# Altium schematic sheet Board_Power.SchDoc(Board_Power)
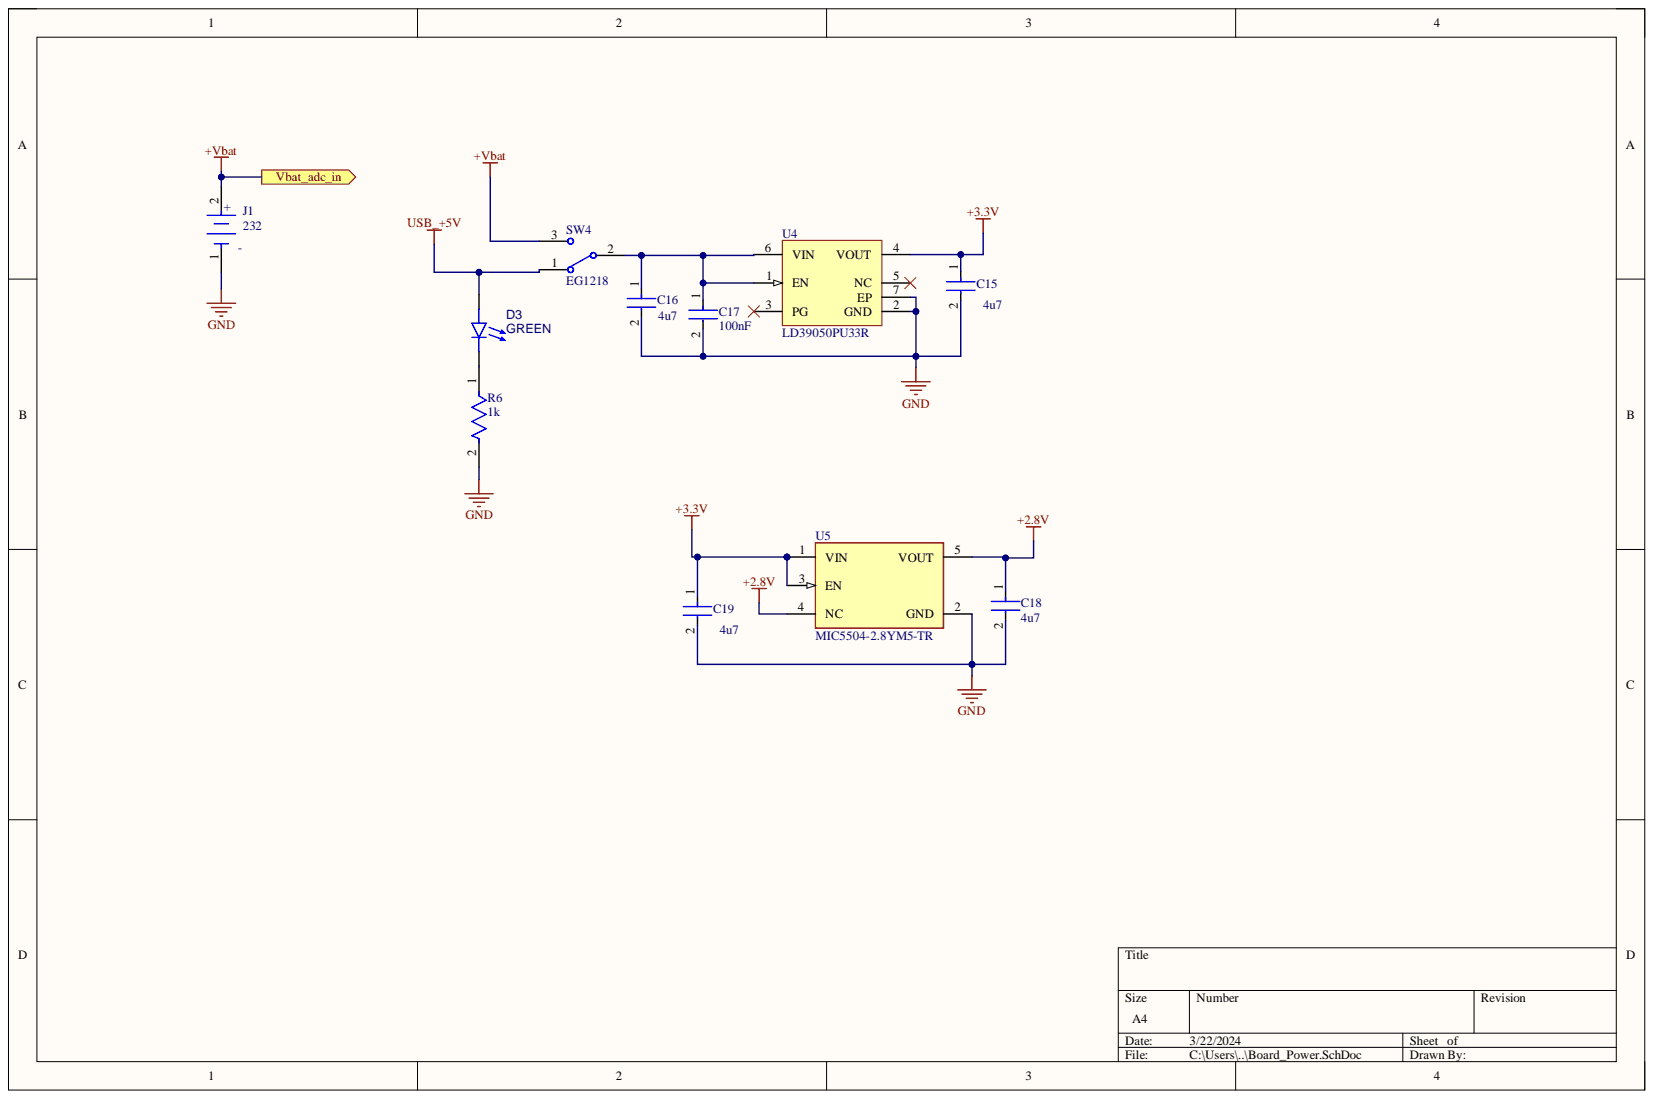
[diagram p0: Board_Power.SchDoc]

## Parts
C15  4u7  [FP-GRM21B-0_15-MFG]
C16  4u7  [FP-GRM21B-0_15-MFG]
C17  100nF  [FP-C1206C-EB-MFG]
C18  4u7  [FP-GRM21B-0_15-MFG]
C19  4u7  [FP-GRM21B-0_15-MFG]
D3  GREEN  [156120VS75000-Footprint-1]
J1  232  [1043P-Footprint-1]
R6  1k  [FP-RMCF1206-MFG]
SW4  EG1218  [ESWI-EG1218_V]
U4  LD39050PU33R  [DFN6D_L]
U5  MIC5504-2.8YM5-TR  [FP-SOT23-5LD-PL-1-IPC_C]

## Ports
Vbat_adc_in

## Nets
+2.8V: C18.1 U5.4(NC) U5.5(VOUT)
+3.3V: C15.1 C19.1 U4.4(VOUT) U5.1(VIN) U5.3(EN)
GND: C15.2 C16.2 C17.2 C18.2 C19.2 J1.1 R6.2 U4.2(GND) U4.7(EP) U5.2(GND)
USB_+5V: D3.2 SW4.1
+Vbat: J1.2 SW4.3 ; port Vbat_adc_in
NetC16_1: C16.1 C17.1 SW4.2 U4.1(EN) U4.6(VIN)
NetD3_1: D3.1 R6.1

Not connected: U4 3(PG) 5(NC)

## Library data of the parts
C15 C16 C18 C19 = CMP-06035-005997-1: Chip Multilayer Ceramic Capacitors for General Purpose, 0805, 4.7uF, X7R, 15%, 10%, 10V; Capacitance: 4.7uF; Case/Package: 0805; Component Kind: Standard; Dielectric: X7R; Length: 2mm; Library Name: Altium Content Vault; Thickness: 1.25mm; Tolerance: 10%; Voltage Rating (DC): 10V; Width: 1.25mm
C17 = CMP-03020-021070-1: CAP CER 0.1UF 16V 10% X7R 1206; Manufacturer: KEMET; Manufacturer Part Number: C1206C104K4RACTU; Automotive Grade: Grade 0; Capacitance: 0.10 μF; Material: Ceramic; Temperature Coefficient: 15%; Tolerance: 0.1; Value: 0.10 μF; Automotive: Yes; Category: Cap; Component Kind: Standard; ComponentLink2Description: Datasheet; ComponentLink2URL: https://content.kemet.com/datasheets/KEM_C1007_X8R_ULTRA_150C_SMD.pdf; Device Class L1: Passive Components; Device Class L2: Capacitors; Device Class L3: Ceramic Capacitors; Digikey Description: CAP CER 0.1UF 16V 10% X7R 1206; Height: 0.88mm; Ipc Land Pattern Name: CAPC320160X78; Lead Free: Yes; Library Name: Altium Content Vault; Mouser Description: Multilayer Ceramic Capacitors MLCC - SMD/SMT 16volts 0.1uF X7R 10%; Octopart: https://octopart.com/c1206c104k4ractu-kemet-12577600; Package: C1206C-EB; RoHS: TRUE; Temperature: -55°C to +150°C; Temperature Characteristic: X7R; Temperature Range High: +150°C; Temperature Range Low: -55°C; Voltage Rating: 16 V
D3 = CMP-007-00036-1: Single Color LED; Component Kind: Standard; RoHS Compliant: Yes; Library Name: tutorial_PCB
J1 = CMP-00029-00001-3: Batteries/Accessories; Component Kind: Standard; Library Name: tutorial_PCB
R6 = CMP-26527-000044-1: 1kΩ ±5% 0.25W 1206 Thick Film Chip Resistor AEC-Q200 compliant; Max Power Dissipation: 250mW; Resistance: 1kR; Temperature Coefficient: 200ppm/°C; Working Voltage: 200V; Case Code (Imperial): 1206; Case Code (Metric): 3216; Case/Package: 1206; Component Kind: Standard; Construction: Rectangular; Contact Plating: Tin; Depth: 1.6mm; Features: Automotive AEC-Q200; Height: 0.028inch; Lead Free: Lead Free; Length: 3.2mm; Library Name: Altium Content Vault; Max Operating Temperature: 155°C; Military Standard: Not; Min Operating Temperature: -55°C; Mount: Surface Mount; Number of Terminations: 2; Packaging: Tape and Reel; Power Rating: 250mW; Radiation Hardening: No; Resistor Type: Thick Film; RoHS Compliant: Yes; Series: RMCF; Tolerance: 5%; Width: 0.063inch
SW4 = CMP-011-00006-2: Slide Switch, SPDT, 500 V, -20 to 70 degC, 3-Pin THD, RoHS, Bulk; Manufacturer: E-Switch; Contact Current Rating: 200mA; Operating Force: 250gf; Voltage Rating (AC): 30V; Case/Package: EG1218; Component Kind: Standard; Contact Finish: Silver; Contact Material: Copper; Contact Plating: Silver; Current Rating: 200mA; Datasheet URL: https://www.e-switch.com/system/asset/product_line/data_sheet/119/EG.pdf; Datasheet Version: Oct-15; Manufacturer URL: http://www.e-switch.ch/en/; Max Operating Temperature: 70°C; Min Operating Temperature: -20°C; Mounting Technology: TH; Package Description: 3-Pin Through Hole Device, Body 11.6 x 4 mm, Pitch 2.5 mm; Package Quantity: 1000; Package Reference: EG1218; Packaging: Bulk; Pins: 3; RoHS Compliant: True; Throw Configuration: SPDT; Voltage Rating (DC): 30V; Library Name: tutorial_PCB
U4 = CMP-0244-00288-1: 500 mA Low Quiescent Current Low Noise Voltage Regulator, 3.3V, 6-Pin VDFDPN, Tape and Reel; Component Kind: Standard; ComponentLink1Description: Manufacturer URL; ComponentLink1URL: http://www.st.com; ComponentLink2Description: Datasheet; ComponentLink2URL: http://www.st.com/internet/com/TECHNICAL_RESOURCES/TECHNICAL_LITERATURE/DATASHEET/CD00227527.pdf; DatasheetVersion: 1/03/2009; Library Name: Altium Content Vault; PackageDescription: 6-Pin Plastic, Dual LLP, 3 x 3 mm Body, 0.95 mm Pitch, Exposed Pad; PackageReference: DFN6D; PackageVersion: 2008
U5 = CMP-06324-000050-1: IC REG LINEAR 2.8V 300MA SOT23-5; Dropout Voltage: 160mV; Max Input Voltage: 5.5V; Min Current Limit: 400mA; Min Input Voltage: 2.5V; Number of Regulators: 1; Output Type: Fixed; Case/Package: SOT-23-5; Component Kind: Standard; Library Name: Altium Content Vault; Max Operating Temperature: 125°C; Min Operating Temperature: -40°C; Mount: Surface Mount; Nominal Output Voltage: 2.8V; Number of Pins: 5; Output Current: 300mA; Output Voltage: 2.8V; Packaging: Cut Tape; REACH SVHC: No SVHC
